# Altium schematic sheet 03_MCU.SchDoc(MCU)
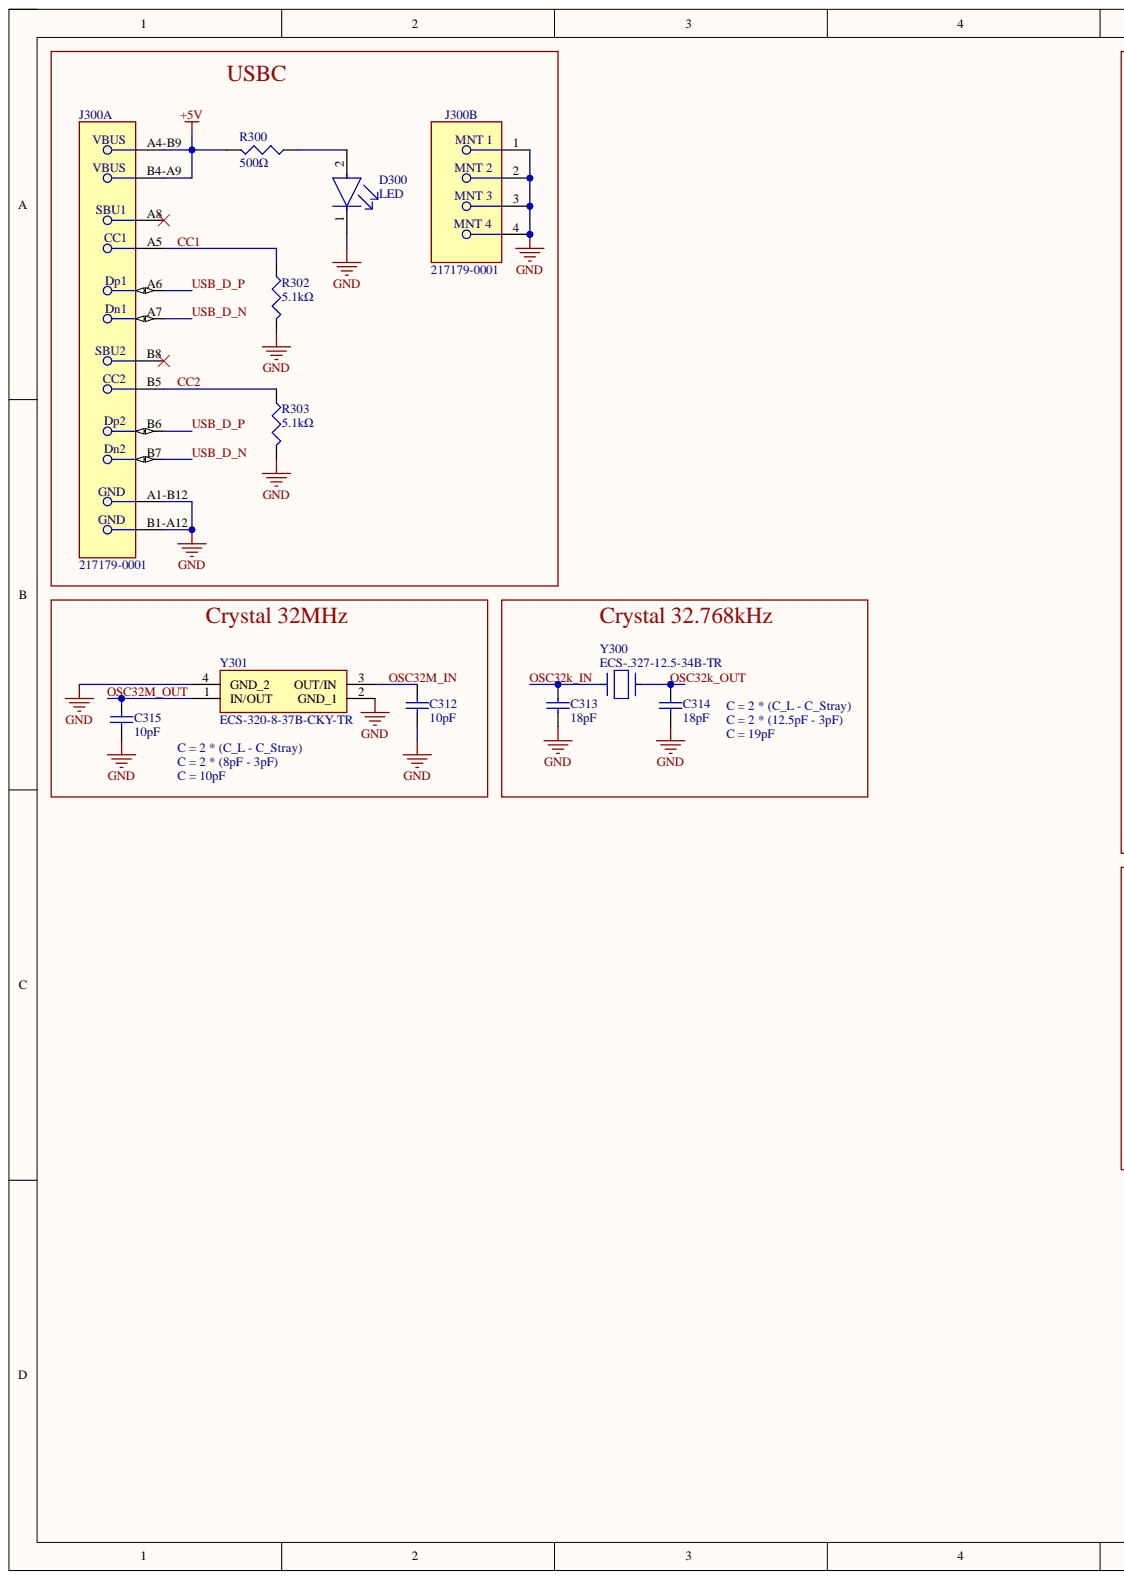
[diagram p0: 03_MCU.SchDoc, left]
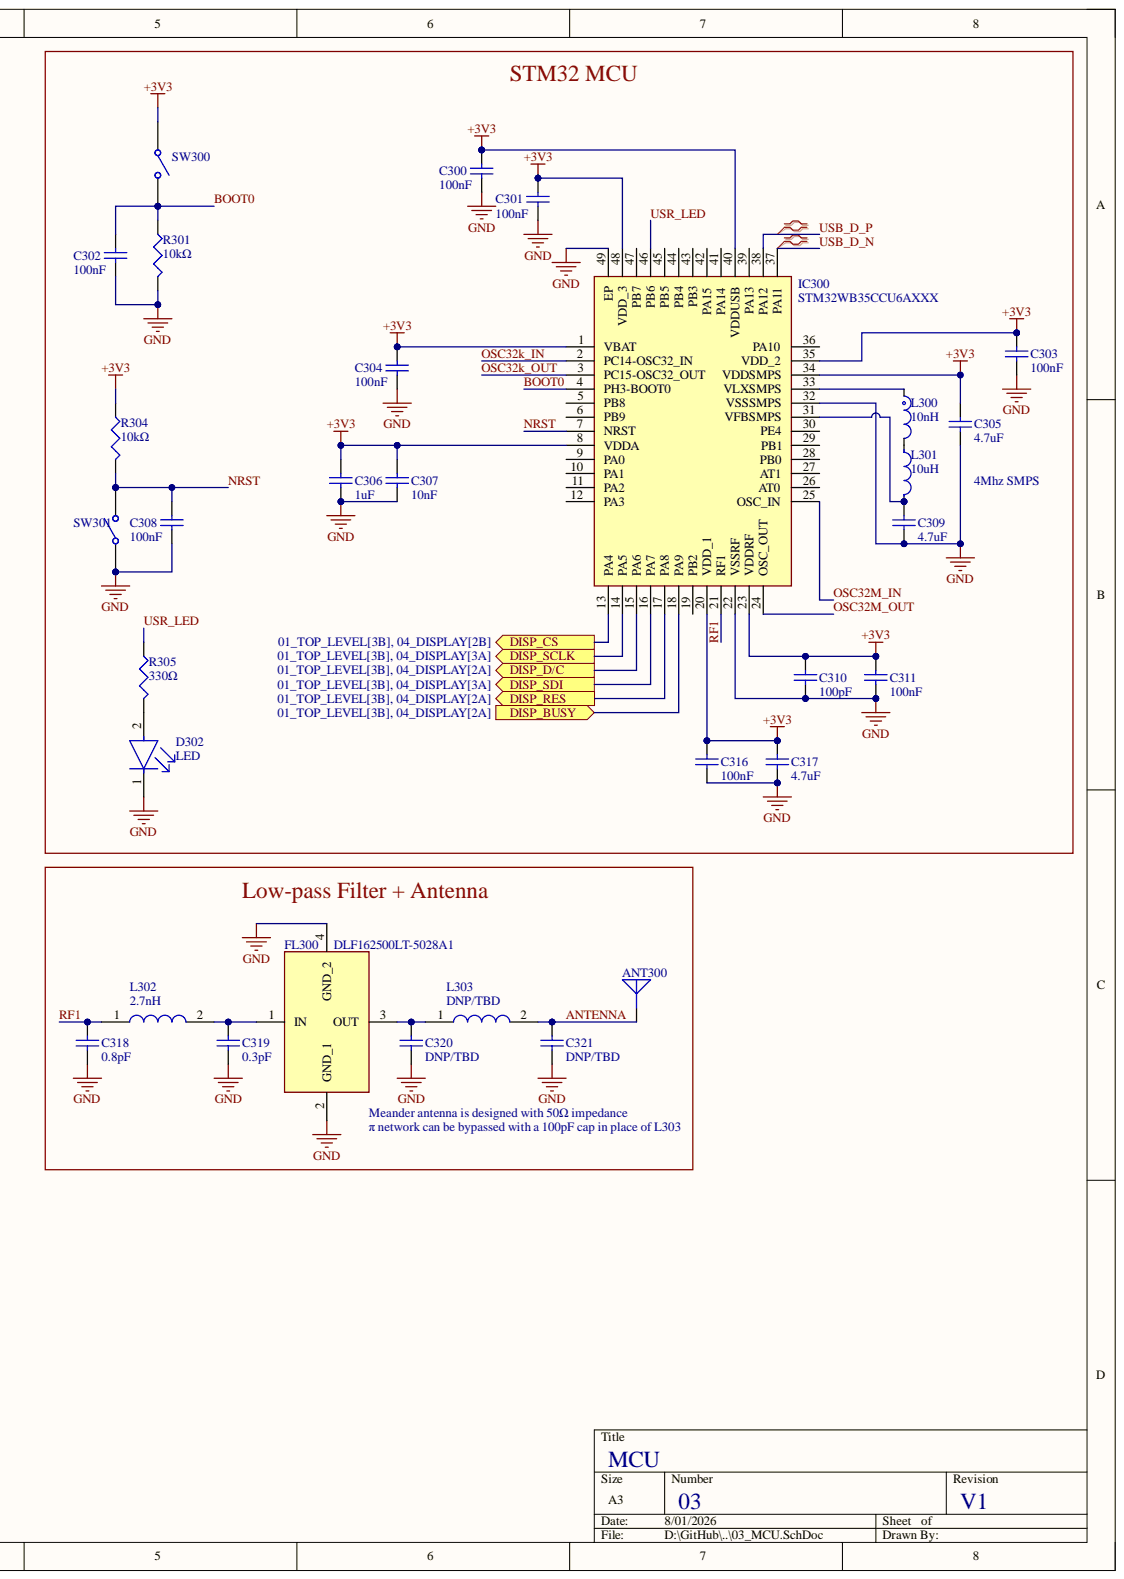
[diagram p1: 03_MCU.SchDoc, right]

## Parts
ANT300  MEANDER_ANTENNA  [MEANDER_ANTENNA]  (value not drawn)
C300  value 100nF  [CAPC1005X55X25LL05T10]  (hidden comment: Capacitor 1 uF +/- 10% 6.3 V 0402)
C301  value 100nF  [CAPC1005X55X25LL05T10]  (hidden comment: Capacitor 1 uF +/- 10% 6.3 V 0402)
C302  value 100nF  [CAPC1005X55X25LL05T10]  (hidden comment: Capacitor 1 uF +/- 10% 6.3 V 0402)
C303  value 100nF  [CAPC1005X55X25LL05T10]  (hidden comment: Capacitor 1 uF +/- 10% 6.3 V 0402)
C304  value 100nF  [CAPC1005X55X25LL05T10]  (hidden comment: Capacitor 1 uF +/- 10% 6.3 V 0402)
C305  value 4.7uF  [CAPC1005X55X25LL05T10]  (hidden comment: Capacitor 1 uF +/- 10% 6.3 V 0402)
C306  value 1uF  [CAPC1005X55X25LL05T10]  (hidden comment: Capacitor 1 uF +/- 10% 6.3 V 0402)
C307  value 10nF  [CAPC1005X55X25LL05T10]  (hidden comment: Capacitor 1 uF +/- 10% 6.3 V 0402)
C308  value 100nF  [CAPC1005X55X25LL05T10]  (hidden comment: Capacitor 1 uF +/- 10% 6.3 V 0402)
C309  value 4.7uF  [CAPC1005X55X25LL05T10]  (hidden comment: Capacitor 1 uF +/- 10% 6.3 V 0402)
C310  value 100pF  [CAPC1005X55X25LL05T10]  (hidden comment: Capacitor 1 uF +/- 10% 6.3 V 0402)
C311  value 100nF  [CAPC1005X55X25LL05T10]  (hidden comment: Capacitor 1 uF +/- 10% 6.3 V 0402)
C312  value 10pF  [CAPC1005X55X25LL05T10]  (hidden comment: Capacitor 1 uF +/- 10% 6.3 V 0402)
C313  value 18pF  [CAPC1005X55X25LL05T10]  (hidden comment: Capacitor 1 uF +/- 10% 6.3 V 0402)
C314  value 18pF  [CAPC1005X55X25LL05T10]  (hidden comment: Capacitor 1 uF +/- 10% 6.3 V 0402)
C315  value 10pF  [CAPC1005X55X25LL05T10]  (hidden comment: Capacitor 1 uF +/- 10% 6.3 V 0402)
C316  value 100nF  [CAPC1005X55X25LL05T10]  (hidden comment: Capacitor 1 uF +/- 10% 6.3 V 0402)
C317  value 4.7uF  [CAPC1005X55X25LL05T10]  (hidden comment: Capacitor 1 uF +/- 10% 6.3 V 0402)
C318  value 0.8pF  [CAPC1005X55X25LL05T10]  (hidden comment: Capacitor 1 uF +/- 10% 6.3 V 0402)
C319  value 0.3pF  [CAPC1005X55X25LL05T10]  (hidden comment: Capacitor 1 uF +/- 10% 6.3 V 0402)
C320  value DNP/TBD  [CAPC1005X55X25LL05T10]  (hidden comment: Capacitor 1 uF +/- 10% 6.3 V 0402)
C321  value DNP/TBD  [CAPC1005X55X25LL05T10]  (hidden comment: Capacitor 1 uF +/- 10% 6.3 V 0402)
D300  LED  [FP-VLMO1500-GS08-MFG]
D302  LED  [FP-VLMO1500-GS08-MFG]
FL300  DLF162500LT-5028A1  [DLF162500LT5028A1]
IC300  STM32WB35CCU6AXXX  [QFN50P700X700X65-49N-D]
J300  217179-0001  [FP-2171790001-MFG]  (parts A,B)
L300  10nH  [FP-LQG15WH_02-MFG]
L301  10uH  [FP-LQM21FN_70-MFG]
L302  2.7nH  [LQG15WH0N7B02D]
L303  DNP/TBD  [LQG15WH0N7B02D]
R300  value 500Ω  [RESC0402(1005)_L]  (hidden comment: 100R2F)
R301  value 10kΩ  [RESC0402(1005)_L]  (hidden comment: 100R2F)
R302  value 5.1kΩ  [RESC0402(1005)_L]  (hidden comment: 100R2F)
R303  value 5.1kΩ  [RESC0402(1005)_L]  (hidden comment: 100R2F)
R304  value 10kΩ  [RESC0402(1005)_L]  (hidden comment: 100R2F)
R305  value 330Ω  [RESC0402(1005)_L]  (hidden comment: 100R2F)
SW300  434111050826  [434111050826]  (value not drawn)
SW301  434111050826  [434111050826]  (value not drawn)
Y300  ECS-.327-12.5-34B-TR  [FP-ECX-31B-MFG]
Y301  ECS-320-8-37B-CKY-TR  [ECS320837BCKYTR]

## Ports
DISP_BUSY, DISP_CS, DISP_D/C, DISP_RES, DISP_SCLK, DISP_SDI

## Nets
+3V3: C300.2 C301.2 C303.2 C304.2 C305.2 C306.2 C307.2 C310.2 C311.2 C316.2 C317.2 IC300.1(VBAT) IC300.8(VDDA) IC300.20(VDD_1) IC300.23(VDDRF) IC300.34(VDDSMPS) IC300.35(VDD_2) IC300.40(VDDUSB) IC300.48(VDD_3) R304.1 SW300.1
+5V: J300.A4-B9 J300.B4-A9 R300.2
GND: C300.1 C301.1 C302.1 C303.1 C304.1 C305.1 C306.1 C307.1 C308.1 C309.1 C310.1 C311.1 C312.1 C313.1 C314.1 C315.1 C316.1 C317.1 C318.1 C319.1 C320.1 C321.1 D300.1 D302.1 FL300.2(GND_1) FL300.4(GND_2) IC300.22(VSSRF) IC300.32(VSSSMPS) IC300.49(EP) J300.1 J300.2 J300.3 J300.4 J300.A1-B12 J300.B1-A12 R301.2 R302.2 R303.2 SW301.1 Y301.2(GND_1) Y301.4(GND_2)
ANTENNA: ANT300.0 C321.2 L303.2
BOOT0: C302.2 IC300.4(PH3-BOOT0) R301.1 SW300.2
CC1: J300.A5 R302.1
CC2: J300.B5 R303.1
DISP_BUSY: IC300.18(PA9) ; port DISP_BUSY
DISP_CS: IC300.13(PA4) ; port DISP_CS
DISP_D/C: IC300.15(PA6) ; port DISP_D/C
DISP_RES: IC300.17(PA8) ; port DISP_RES
DISP_SCLK: IC300.14(PA5) ; port DISP_SCLK
DISP_SDI: IC300.16(PA7) ; port DISP_SDI
NRST: C308.2 IC300.7(NRST) R304.2 SW301.2
OSC32k_IN: C313.2 IC300.2(PC14-OSC32_IN) Y300.1
OSC32k_OUT: C314.2 IC300.3(PC15-OSC32_OUT) Y300.2
OSC32M_IN: C312.2 IC300.25(OSC_IN) Y301.3(OUT/IN)
OSC32M_OUT: C315.2 IC300.24(OSC_OUT) Y301.1(IN/OUT)
RF1: C318.2 IC300.21(RF1) L302.1
USB_D_N: IC300.37(PA11) J300.A7 J300.B7
USB_D_P: IC300.38(PA12) J300.A6 J300.B6
USR_LED: IC300.46(PB6) R305.1
NetC309_2: C309.2 IC300.31(VFBSMPS) L301.2
NetC319_2: C319.2 FL300.1(IN) L302.2
NetC320_2: C320.2 FL300.3(OUT) L303.1
NetD300_2: D300.2 R300.1
NetD302_2: D302.2 R305.2
NetIC300_5: IC300.5(PB8)
NetIC300_6: IC300.6(PB9)
NetIC300_9: IC300.9(PA0)
NetIC300_10: IC300.10(PA1)
NetIC300_11: IC300.11(PA2)
NetIC300_12: IC300.12(PA3)
NetIC300_19: IC300.19(PB2)
NetIC300_26: IC300.26(AT0)
NetIC300_27: IC300.27(AT1)
NetIC300_28: IC300.28(PB0)
NetIC300_29: IC300.29(PB1)
NetIC300_30: IC300.30(PE4)
NetIC300_33: IC300.33(VLXSMPS) L300.1
NetIC300_36: IC300.36(PA10)
NetIC300_39: IC300.39(PA13)
NetIC300_41: IC300.41(PA14)
NetIC300_42: IC300.42(PA15)
NetIC300_43: IC300.43(PB3)
NetIC300_44: IC300.44(PB4)
NetIC300_45: IC300.45(PB5)
NetIC300_47: IC300.47(PB7)
NetJ300_A8: J300.A8
NetJ300_B8: J300.B8
NetL300_2: L300.2 L301.1

## Library data of the parts
ANT300 = MEANDER_ANTENNA: 2.4GHz meander antenna; Component Kind: Standard; ComponentLink1Description: ST Guidelines; ComponentLink1URL: https://www.st.com/resource/en/application_note/an5129-guidelines-for-meander-design-using-lowcost-pcb-antennae-with-24-ghz-radio-for-stm32wbwb0-mcus-stmicroelectronics.pdf; Library Name: Schlib1.SchLib
C300-C321 = CMP-001-00008-6: Chip Capacitor, 1 uF, +/- 10%, 6.3 V, 0402 (1005 Metric); Capacitor Type: Ceramic; Voltage Rating: 6.3V; Case/Package: 0402; Component Kind: Standard; Max Operating Temperature: 85°C; Min Operating Temperature: -55°C; Mounting Technology: SM; Pins: 2; RoHS Compliant: True; Tolerance: 10%; Library Name: CPRT Workspace
D300 D302 = CMP-00030-24098164-1: VLMO1500-GS08 LED Uni-Color Soft Orange 611nm 2-Pin Chip 0402(1006Metric) T/R; Dominant Wavelength: 605nm; Forward Current: 20mA; Forward Voltage: 2V; Lens Style: Rectangular, Square; Luminous Intensity: 90mcd; Number of LEDs: 1; Power Dissipation: 75mW; Reverse Voltage: 5V; Viewing Angle: 130°; Ambient Temperature Range High: 85°C; Case Code (Imperial): 0402; Case Code (Metric): 1005; Case/Package: 0402; Color: Orange; Component Kind: Standard; Height: 350µm; Illumination Color: Orange; Lead Free: Lead Free; Length: 1mm; Library Name: Altium Content Vault; Max Operating Temperature: 85°C; Min Operating Temperature: -30°C; Mount: Surface Mount; NexarPartId: 24098164; Number of Pins: 2; Packaging: Cut Tape; Power Rating: 75mW; Radiation Hardening: No; RoHS: Compliant; Schedule B: 8541402000, 8541402000; Test Current: 20mA; Wavelength: 605nm; Width: 500µm
FL300 = DLF162500LT-5028A1: Filter; Manufacturer_Name: TDK; Arrow Part Number: DLF162500LT-5028A1; Arrow Price/Stock: https://www.arrow.com/en/products/dlf162500lt-5028a1/tdk?utm_currency=USD&region=nac; Component Kind: Standard; Datasheet Link: https://product.tdk.com/system/files/dam/doc/product/rf/rf/filter/catalog/rf_lpf_dlf162500lt-5028a1_en.pdf; Height: 0.4mm; Library Name: Schlib1.SchLib; Manufacturer_Part_Number: DLF162500LT-5028A1; Mouser Part Number: 810-DLF16250LT5028A1; Mouser Price/Stock: https://www.mouser.co.uk/ProductDetail/TDK/DLF162500LT-5028A1?qs=U%2FZX79kHR%2FlwIbAISCs3qA%3D%3D
IC300 = STM32WB35CCU6AXXX: Integrated Circuit; Manufacturer_Name: STMicroelectronics; Component Kind: Standard; ComponentLink1Description: DigiKey; ComponentLink1URL: https://www.digikey.ca/en/products/detail/stmicroelectronics/STM32WB35CCU6A/13282469; Datasheet Link: https://www.st.com/resource/en/datasheet/stm32wb35cc.pdf; Height: 0.65mm; Library Name: Schlib1.SchLib; Manufacturer_Part_Number: STM32WB35CCU6AXXX
J300 = CMP-04767-000028-2: CONN RCP USB2.0 TYP C 24P SMD RA; NexarPartId: 117190526; Current Rating: 1.25A; Gender: Receptacle; Number of Contacts: 16; Voltage Rating: 30V; Component Kind: Standard; Contact Material: Gold, Nickel; Height: 3.36mm; Library Name: Altium Content Vault; Max Operating Temperature: 85°C; Min Operating Temperature: -40°C; USB Standard: USB 2.0
L300 = CMP-06042-005237-1: Multilayer type Inductor for Automotive 10nH ±5% 0.19Ω 500mA 0402; Core Material: Air; DC Resistance (DCR): 190mR; Self Resonant Frequency: 3.3GHz; Shielding: Unshielded; Test Frequency: 100MHz; Case Code (Imperial): 0402; Case Code (Metric): 1005; Case/Package: 0402; Component Kind: Standard; Current Rating: 500mA; Inductance: 10nH; Library Name: Altium Content Vault; Max Operating Temperature: 125°C; Min Operating Temperature: -55°C; Mount: Surface Mount; Packaging: Cut Tape; Ratings: AEC-Q200; Tolerance: 5%
L301 = CMP-2000-06587-2: FIXED IND 10UH 100MA 780MOHM SMD; Core Material: Ferrite; DC Resistance (DCR): 780mR; Self Resonant Frequency: 15MHz; Shielding: Shielded; Test Frequency: 1MHz; Tolerance: 20%; Case Code (Imperial): 0805; Case Code (Metric): 2012; Case/Package: 0805; Component Kind: Standard; Current Rating: 100mA; DC Current: 100mA; Depth: 1.45mm; Height: 1.45mm; Height - Seated (Max): 0.057inch; Inductance: 10uH; Lead Free: Lead Free; Length: 2.2mm; Library Name: Altium Content Vault; Max DC Current: 100mA; Max Operating Temperature: 125°C; Military Standard: Not; Min Operating Temperature: -55°C; Mount: Surface Mount; Package Quantity: 3000; Packaging: Tape and Reel; Radiation Hardening: No; Rated Current-Max: 100mA; RoHS Compliant: Yes; Saturation Current: 100mA; Series: LQM21F_70; Termination: SMD/SMT; Width: 0.049inch
L302 L303 = LQG15HS2N7S02D: Inductor; Manufacturer_Name: Murata Electronics; Arrow Part Number: LQG15HS2N7S02D; Arrow Price/Stock: https://www.arrow.com/en/products/lqg15hs2n7s02d/murata-manufacturing?utm_currency=USD&region=nac; Component Kind: Standard; Datasheet Link: https://search.murata.co.jp/Ceramy/image/img/P02/JELF243B-0010.pdf; Height: 0.6mm; Library Name: Schlib1.SchLib; Manufacturer_Part_Number: LQG15HS2N7S02D; Mouser Part Number: 81-LQG15HS2N7S02D; Mouser Price/Stock: https://www.mouser.co.uk/ProductDetail/Murata-Electronics/LQG15HS2N7S02D?qs=ctz47l0uL1AKrLI74yO5Qw%3D%3D
R300-R305 = CMP-009-00065-2: 100R 0.063W 1% 0402 (1005 Metric)  SMD; Power: 63mW; Case/Package: 0402; Component Kind: Standard; Mounting Technology: SMT; Pins: 2; Tolerance: 1%; Library Name: CPRT Workspace
SW300 SW301 = CMP-1459-00003-6: Switch Tactile Spst-no 0.05A 12V; Manufacturer Lifecycle Status: ACTIVE; Actuator Length: 1.5mm; Actuator Material: Liquid Crystal Polymer, Polymer; Contact Current Rating: 50mA; Contact Resistance: 100mΩ; Illumination Type: Illuminated, Non-Illuminated; Mechanical Life: 30kcycles; Operating Force: 260N; Switch Function: Off-Mom; Switch Travel: 250µm; Throw Configuration: SPST; YEOL: 1 = Estimated lifetime > 10 years; Component Kind: Standard; Contact Material: Stainless Steel, Steel; Contact Plating: Silver; Current Rating: 50mA; Height: 5mm; Insulation Resistance: 100MΩ; Length: 8mm; Library Name: Altium Content Vault; Lifecycle Status: Production; Max Operating Temperature: 85°C; Min Operating Temperature: -40°C; Mount: Surface Mount; Packaging: Tape and Reel; REACH SVHC: No; RoHS: Compliant; Termination: SMD/SMT; Voltage Rating: 12V
Y300 = CMP-40224-000020-1: CRYSTAL 32.7680KHZ 12.5PF SMD; ESR (Equivalent Series Resistance): 70kR; Frequency: 32.768kHz; Frequency Tolerance: 20ppm; Case/Package: SMD/SMT; Component Kind: Standard; Height: 0.035inch; Length: 0.126inch; Library Name: Altium Content Vault; Load Capacitance: 12.5pF; Max Operating Temperature: 85°C; Min Operating Temperature: -40°C; Mount: Surface Mount; Number of Pins: 2; Package Quantity: 3000; Packaging: Tape and Reel; Termination: SMD/SMT; Width: 0.059inch
Y301 = ECS-320-8-37B-CKY-TR: Crystal or Oscillator; Manufacturer_Name: ECS; Arrow Part Number: ECS-320-8-37B-CKY-TR; Arrow Price/Stock: https://www.arrow.com/en/products/ecs-320-8-37b-cky-tr/ecs-international?region=nac; Component Kind: Standard; Datasheet Link: https://ecsxtal.com/store/pdf/ECX-1637B.pdf; Height: 0.5mm; Library Name: Schlib1.SchLib; Manufacturer_Part_Number: ECS-320-8-37B-CKY-TR; Mouser Part Number: 520-320-8-37B-CKYT; Mouser Price/Stock: https://www.mouser.co.uk/ProductDetail/ECS/ECS-320-8-37B-CKY-TR?qs=l7cgNqFNU1jF5E15eCxZkA%3D%3D
